# Altium schematic sheet misc.SchDoc(misc)
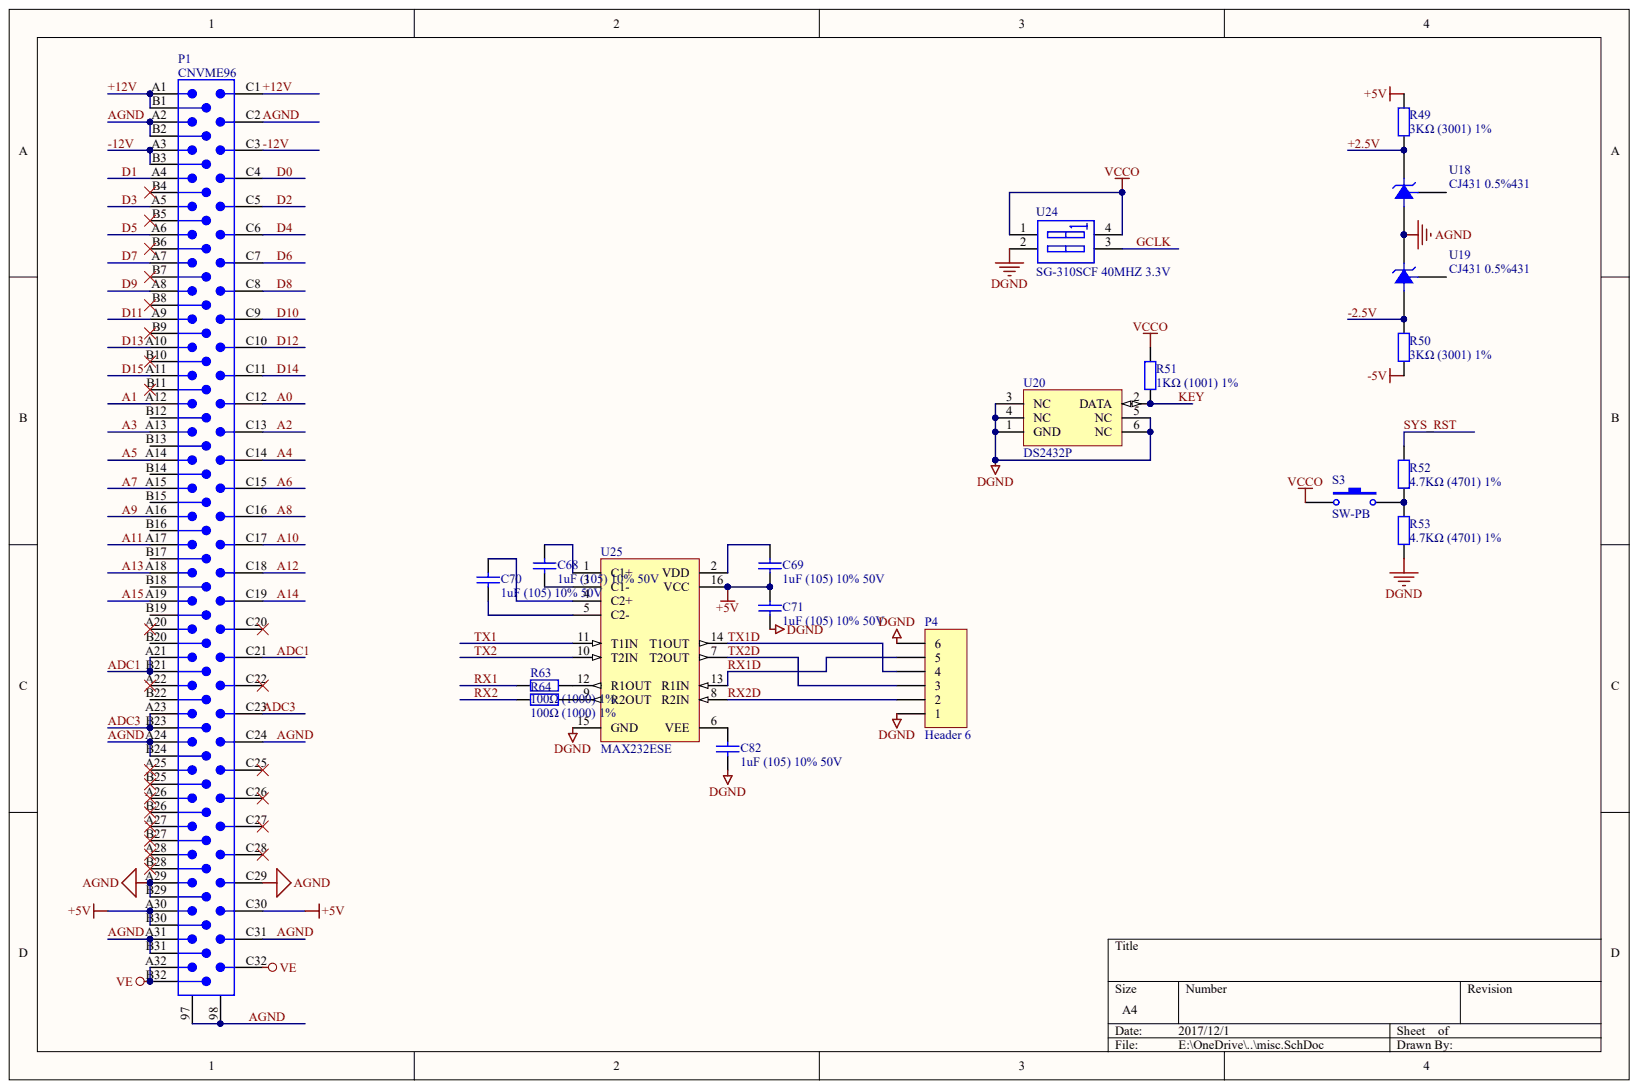
[diagram p0: misc.SchDoc]

## Parts
C68  1uF (105) 10% 50V
C69  1uF (105) 10% 50V
C70  1uF (105) 10% 50V
C71  1uF (105) 10% 50V
C82  1uF (105) 10% 50V
P1  CNVME96
P4  Header 6
R49  3KΩ (3001) ±1%  (value not drawn)
R50  3KΩ (3001) ±1%  (value not drawn)
R51  1KΩ (1001) ±1%  (value not drawn)
R52  4.7KΩ (4701) ±1%
R53  4.7KΩ (4701) ±1%
R63  100Ω (1000) ±1%
R64  100Ω (1000) ±1%
S3  SW-PB
U18  CJ431 0.5%431
U19  CJ431 0.5%431
U20  DS2432P
U24  SG-310SCF 40MHZ 3.3V
U25  MAX232ESE

## Nets
+5V: C69.1 C71.2 P1.A30 P1.B30 P1.C30 R49.2 U25.16(VCC)
-5V: R50.1
DGND: C71.1 C82.1 P4.1 P4.6 R53.1 U20.1(GND) U20.3(NC) U20.4(NC) U20.5(NC) U20.6(NC) U24.2 U25.15(GND)
VCCO: R51.2 S3.1 U24.1 U24.4
VE: P1.A32 P1.B32 P1.C32
+2.5V: R49.1 U18.2
+12V: P1.A1 P1.B1 P1.C1
-2.5V: R50.2 U19.3
-12V: P1.A3 P1.B3 P1.C3
A0: P1.C12
A1: P1.A12
A2: P1.C13
A3: P1.A13
A4: P1.C14
A5: P1.A14
A6: P1.C15
A7: P1.A15
A8: P1.C16
A9: P1.A16
A10: P1.C17
A11: P1.A17
A12: P1.C18
A13: P1.A18
A14: P1.C19
A15: P1.A19
ADC1: P1.A21 P1.B21 P1.C21
ADC3: P1.A23 P1.B23 P1.C23
AGND: P1.97 P1.98 P1.A2 P1.A24 P1.A29 P1.A31 P1.B2 P1.B24 P1.B29 P1.B31 P1.C2 P1.C24 P1.C29 P1.C31 U18.3 U19.2
D0: P1.C4
D1: P1.A4
D2: P1.C5
D3: P1.A5
D4: P1.C6
D5: P1.A6
D6: P1.C7
D7: P1.A7
D8: P1.C8
D9: P1.A8
D10: P1.C9
D11: P1.A9
D12: P1.C10
D13: P1.A10
D14: P1.C11
D15: P1.A11
GCLK: U24.3
KEY: R51.1 U20.2(DATA)
RX1: R63.1
RX1D: P4.5 U25.13(R1IN)
RX2: R64.1
RX2D: P4.2 U25.8(R2IN)
SYS_RST: R52.2
TX1: U25.11(T1IN)
TX1D: P4.4 U25.14(T1OUT)
TX2: U25.10(T2IN)
TX2D: P4.3 U25.7(T2OUT)
NetC68_1: C68.1 U25.3(C1-)
NetC68_2: C68.2 U25.1(C1+)
NetC69_2: C69.2 U25.2(VDD)
NetC70_1: C70.1 U25.5(C2-)
NetC70_2: C70.2 U25.4(C2+)
NetC82_2: C82.2 U25.6(VEE)
NetR52_1: R52.1 R53.2 S3.2
NetR63_2: R63.2 U25.12(R1OUT)
NetR64_2: R64.2 U25.9(¡À1%)

Not connected: P1 A20 A22 A25 A26 A27 A28 B4 B5 B6 B7 B8 B9 B10 B11 B12 B13 B14 B15 B16 B17 B18 B19 B20 B22 B25 B26 B27 B28 C20 C22 C25 C26 C27 C28; U18 1; U19 1

## Library data of the parts
P1 = (no symbol name): Text Field6: Alternate Layout Available; Text Field7: Published 14-Feb-2002
U20 = (no symbol name): Note: Pin numbers 3-6 are Not Connected (NC); Published: 13-Oct-2000; LatestRevisionDate: 17-Jul-2002; LatestRevisionNote: Re-released for DXP Platform.; DatasheetVersion: 21-Oct-1999; PackageVersion: 1999; PackageReference: TSOC6; PackageDescription: Small Outline; 6 C-Bend Leads; Body Width 3.8 mm; Pitch 1.27 mm; ComponentLink1Description: Manufacturer Link; ComponentLink1URL: http://www.maxim-ic.com/; ComponentLink2Description: Datasheet; ComponentLink2URL: http://pdfserv.maxim-ic.com/en/ds/DS2430A.pdf; Publisher: Altium Limited
C68-C71 C82 P4 R49-R53 R63 R64 S3 U18 U19 U24 U25 = (no symbol name)
  C68-C71 C82: ComponentLink1Description: 供应商链接; ComponentLink1URL: http://www.szlcsc.com/product/details_29074.html; Package: 0805; Supplier: LC; SuppliersPartNumber: C28323
  P4: LatestRevisionDate: 17-Jul-2002; LatestRevisionNote: Re-released for DXP Platform.
  R49 R50: ComponentLink1Description: 供应商链接; ComponentLink1URL: http://www.szlcsc.com/product/details_18349.html; Package: 0805; Supplier: LC; SuppliersPartNumber: C17661
  R51: ComponentLink1Description: 供应商链接; ComponentLink1URL: http://www.szlcsc.com/product/details_18201.html; Package: 0805; Supplier: LC; SuppliersPartNumber: C17513
  R52 R53: ComponentLink1Description: 供应商链接; ComponentLink1URL: http://www.szlcsc.com/product/details_18361.html; Package: 0805; Supplier: LC; SuppliersPartNumber: C17673
  R63 R64: ComponentLink1Description: 供应商链接; ComponentLink1URL: http://www.szlcsc.com/product/details_18096.html; Package: 0805; Supplier: LC; SuppliersPartNumber: C17408
  S3: Published: 24-Mar-1999; LatestRevisionDate: 17-Jul-2002; LatestRevisionNote: Re-released for DXP Platform.; PackageReference: SPST-2; PackageDescription: Switch; 2 Leads
  U18 U19: ComponentLink1Description: 供应商链接; ComponentLink1URL: http://www.szlcsc.com/product/details_3500.html; Package: SOT-23; Supplier: LC; SuppliersPartNumber: C3113
  U24: Published: 24-Mar-1999; LatestRevisionDate: 17-Jul-2002; LatestRevisionNote: Re-released for DXP Platform.; PackageReference: DIP-4
  U25: ComponentLink1Description: 供应商链接; ComponentLink1URL: http://www.szlcsc.com/product/details_27608.html; Package: SOIC-16_150mil; Supplier: LC; SuppliersPartNumber: C26860
  P4 S3 U24: Publisher: Altium Limited
